# Altium schematic sheet [5]EEPROM.SchDoc([5]EEPROM)
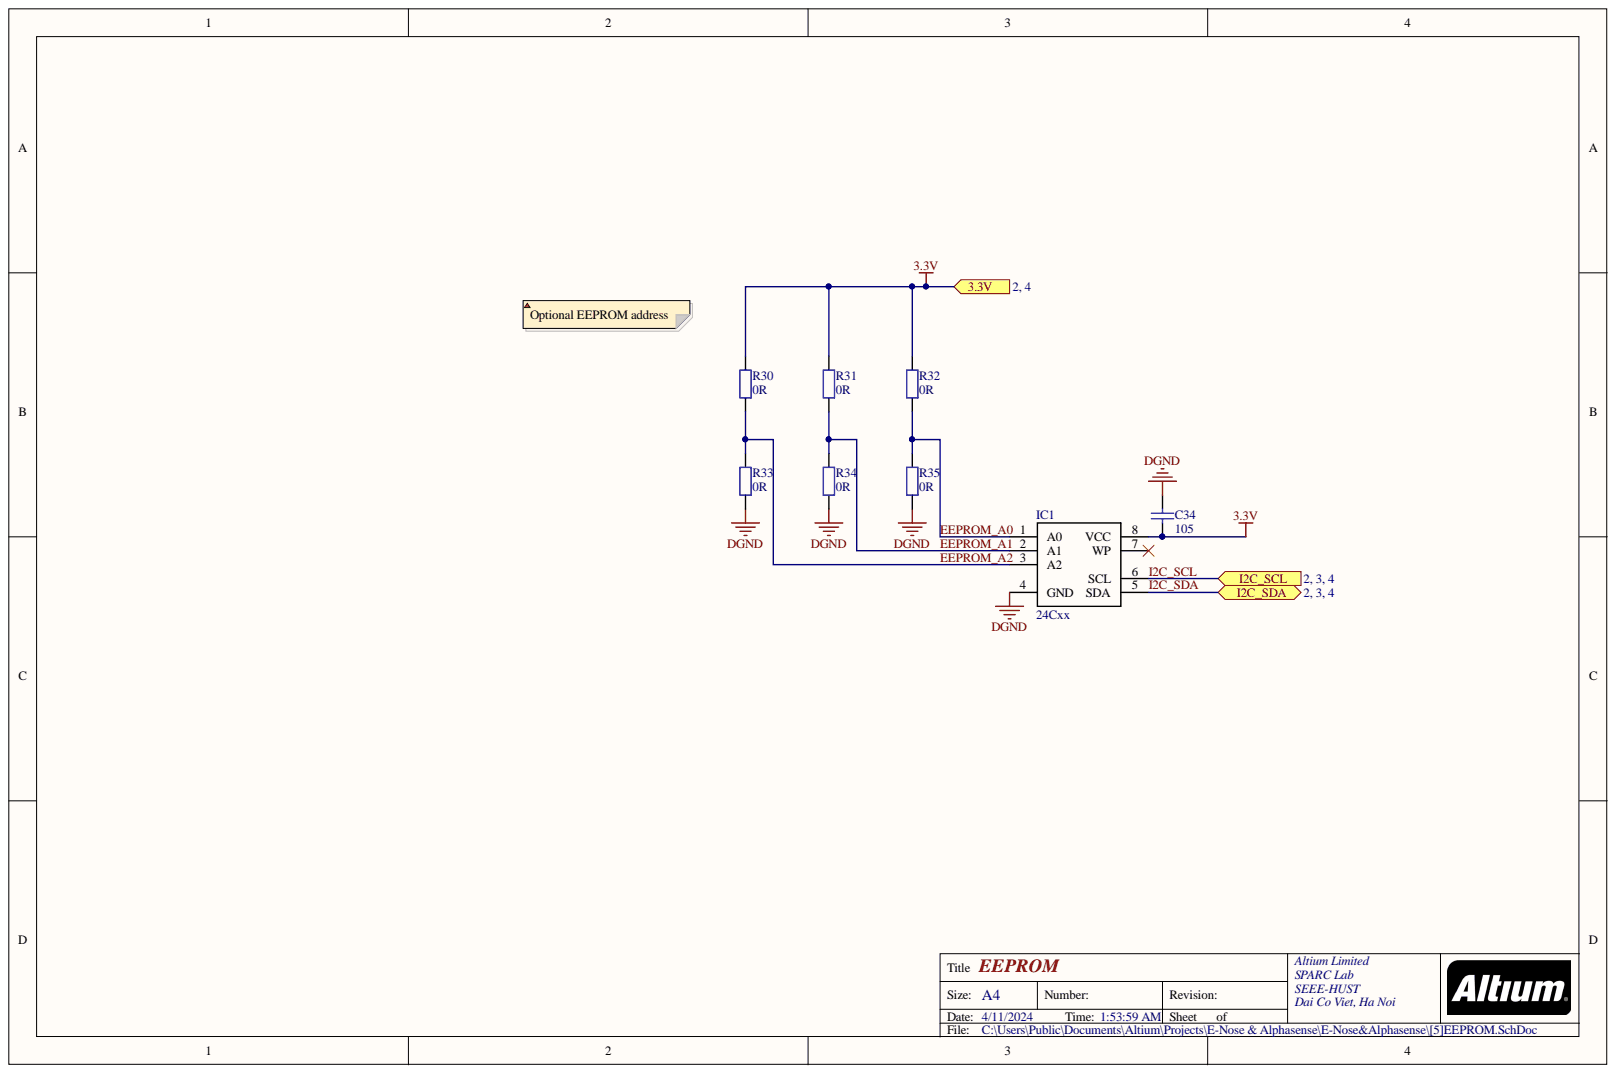
[diagram p0: [5]EEPROM.SchDoc]

## Parts
C34  105  [tu 0805]
IC1  24Cxx  [SO8]
R30  0R  [tro smd 0805]
R31  0R  [tro smd 0805]
R32  0R  [tro smd 0805]
R33  0R  [tro smd 0805]
R34  0R  [tro smd 0805]
R35  0R  [tro smd 0805]

## Ports
3.3V, I2C_SCL, I2C_SDA

## Nets
DGND: C34.1 IC1.4(GND) R33.1 R34.1 R35.1
3.3V: C34.2 IC1.8(VCC) R30.2 R31.2 R32.2 ; port 3.3V
EEPROM_A0: IC1.1(A0) R32.1 R35.2
EEPROM_A1: IC1.2(A1) R31.1 R34.2
EEPROM_A2: IC1.3(A2) R30.1 R33.2
I2C_SCL: IC1.6(SCL) ; port I2C_SCL
I2C_SDA: IC1.5(SDA) ; port I2C_SDA

Not connected: IC1 7(WP)

## Library data of the parts
C34 = Tu 0805 105 50V 10%: Dán; Ten_Linh_Kien: Tụ 0805 105-1uF 10% 50V; So_Chan: 2; Ma_Hang: MH004552; Don_Vi: Con; Library Name: Tu dien.SchLib; Component Kind: Standard; Chung_Loai: Dán
IC1 = 24Cxx: Standard 2-Wire Bus Interface Serial EEPROM; Value: DIP 8; Value: 24Cxx; Library Name: LIBRARY.IntLib; Component Kind: Standard; Copyright by: VanDungEvn
R30-R35 = Tro 0805 5% 0R: Dán; Ten_Linh_Kien: Trở 0805 5% 0R; So_Chan: 2; Ma_Hang: MH005230; Don_Vi: Con; Library Name: Dien Tro.SchLib; Component Kind: Standard; Chung_Loai: Dán
